# Altium schematic sheet 07 Power2.SchDoc
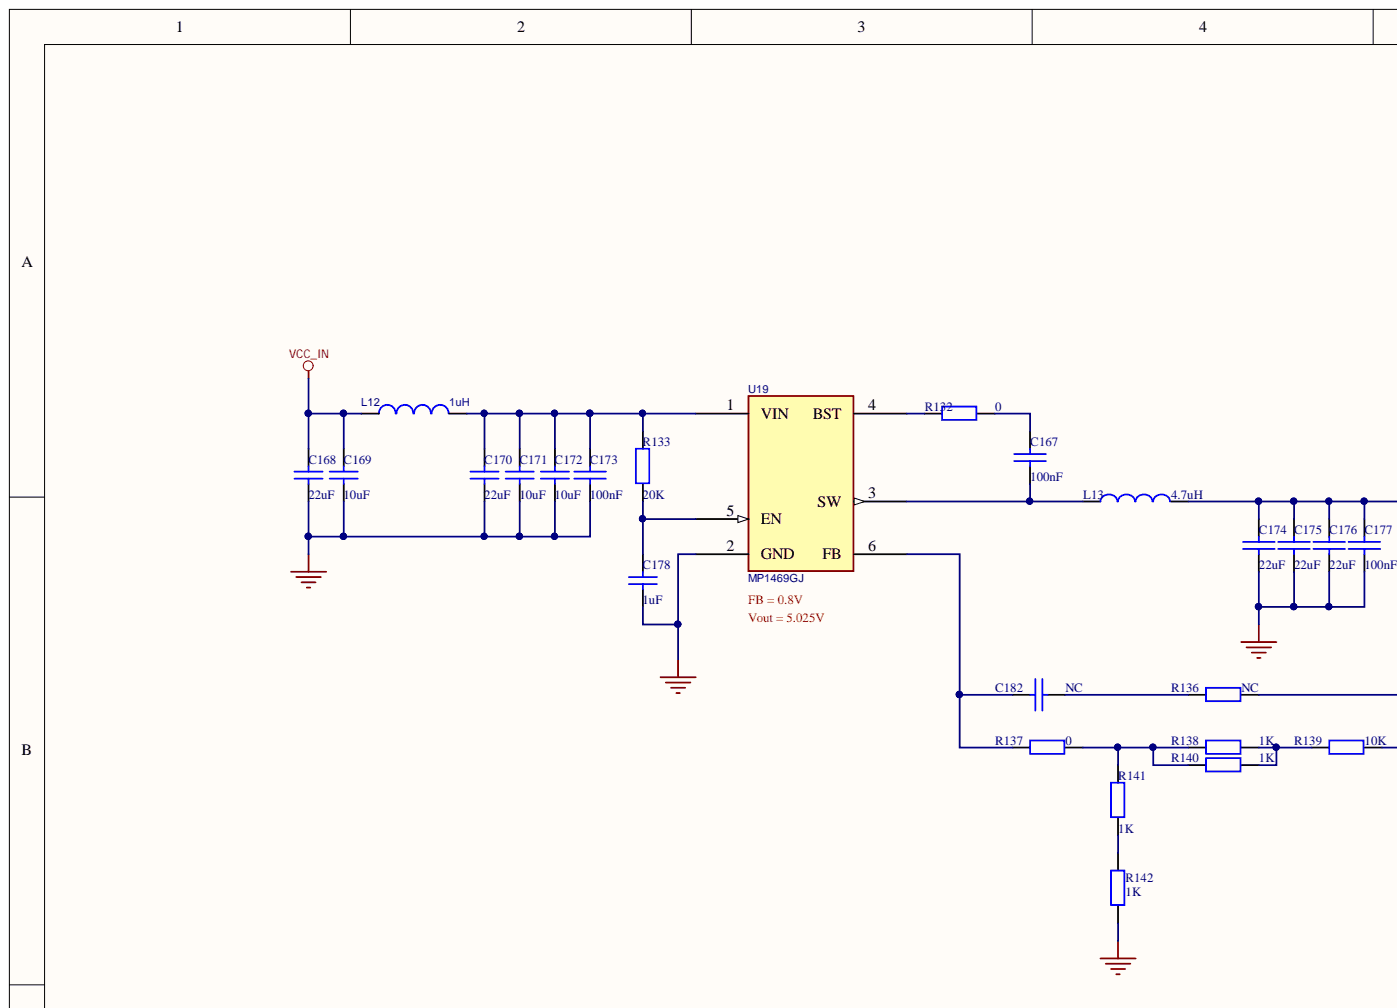
[diagram p0: 07 Power2.SchDoc, top left]
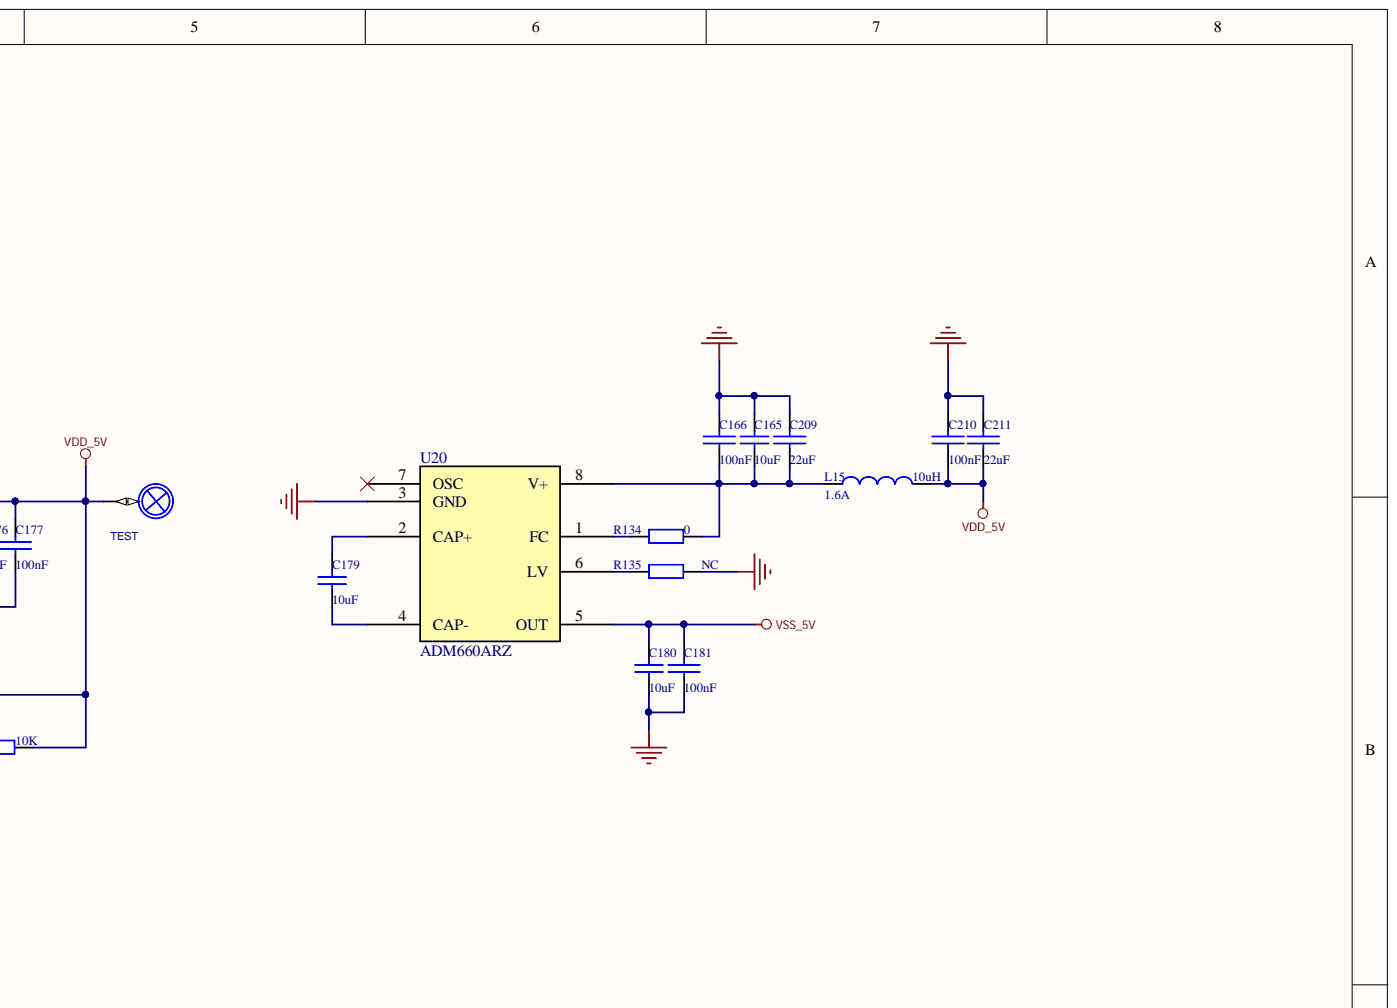
[diagram p1: 07 Power2.SchDoc, top right]
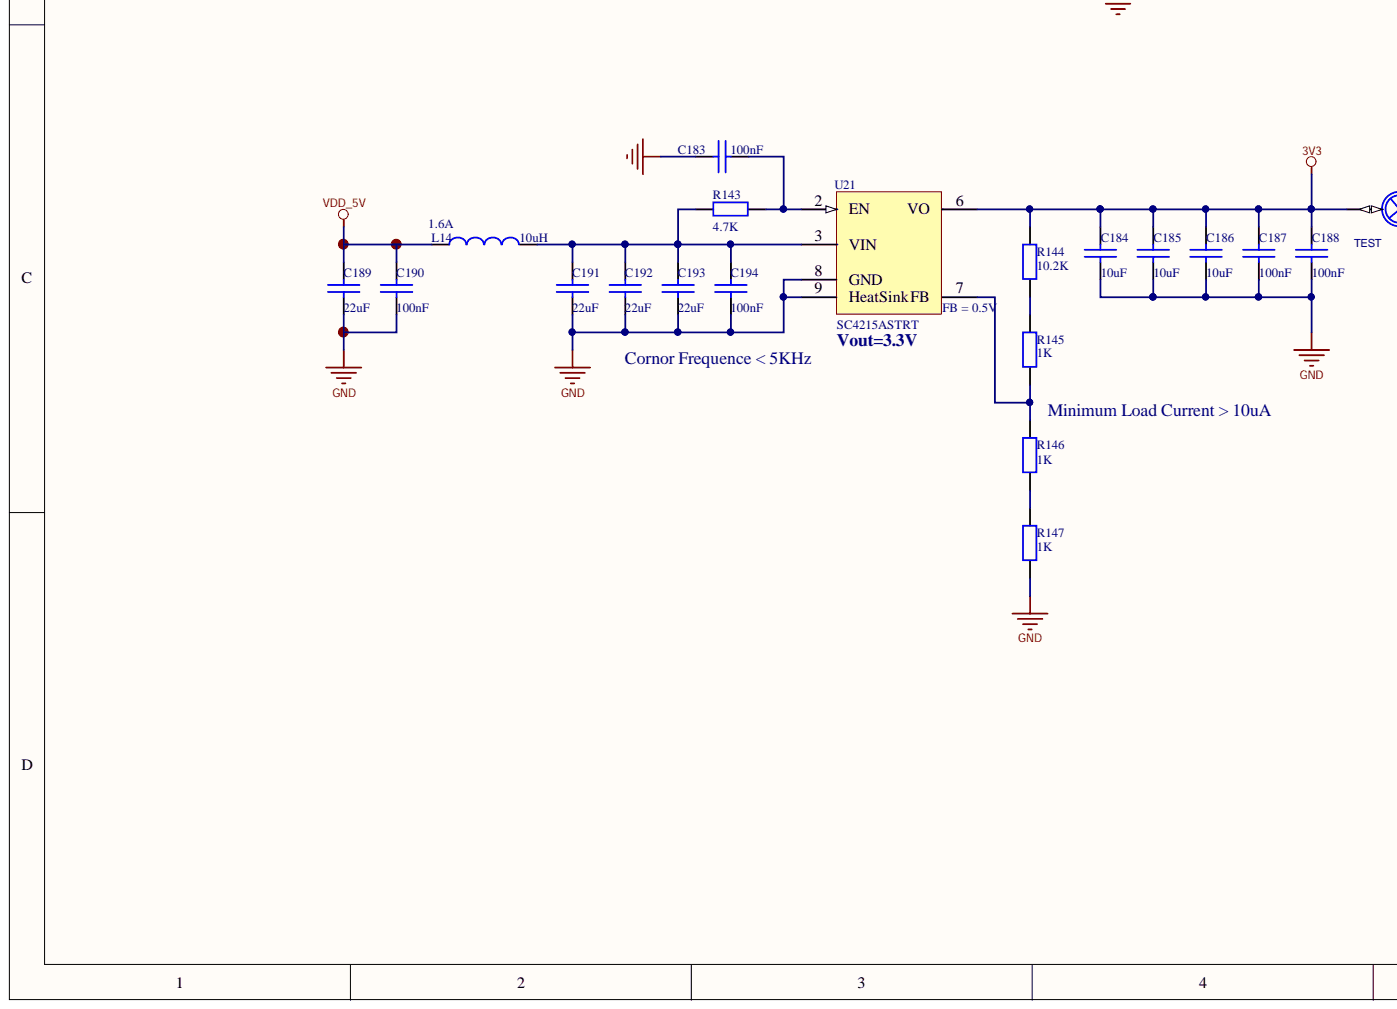
[diagram p2: 07 Power2.SchDoc, bottom left]
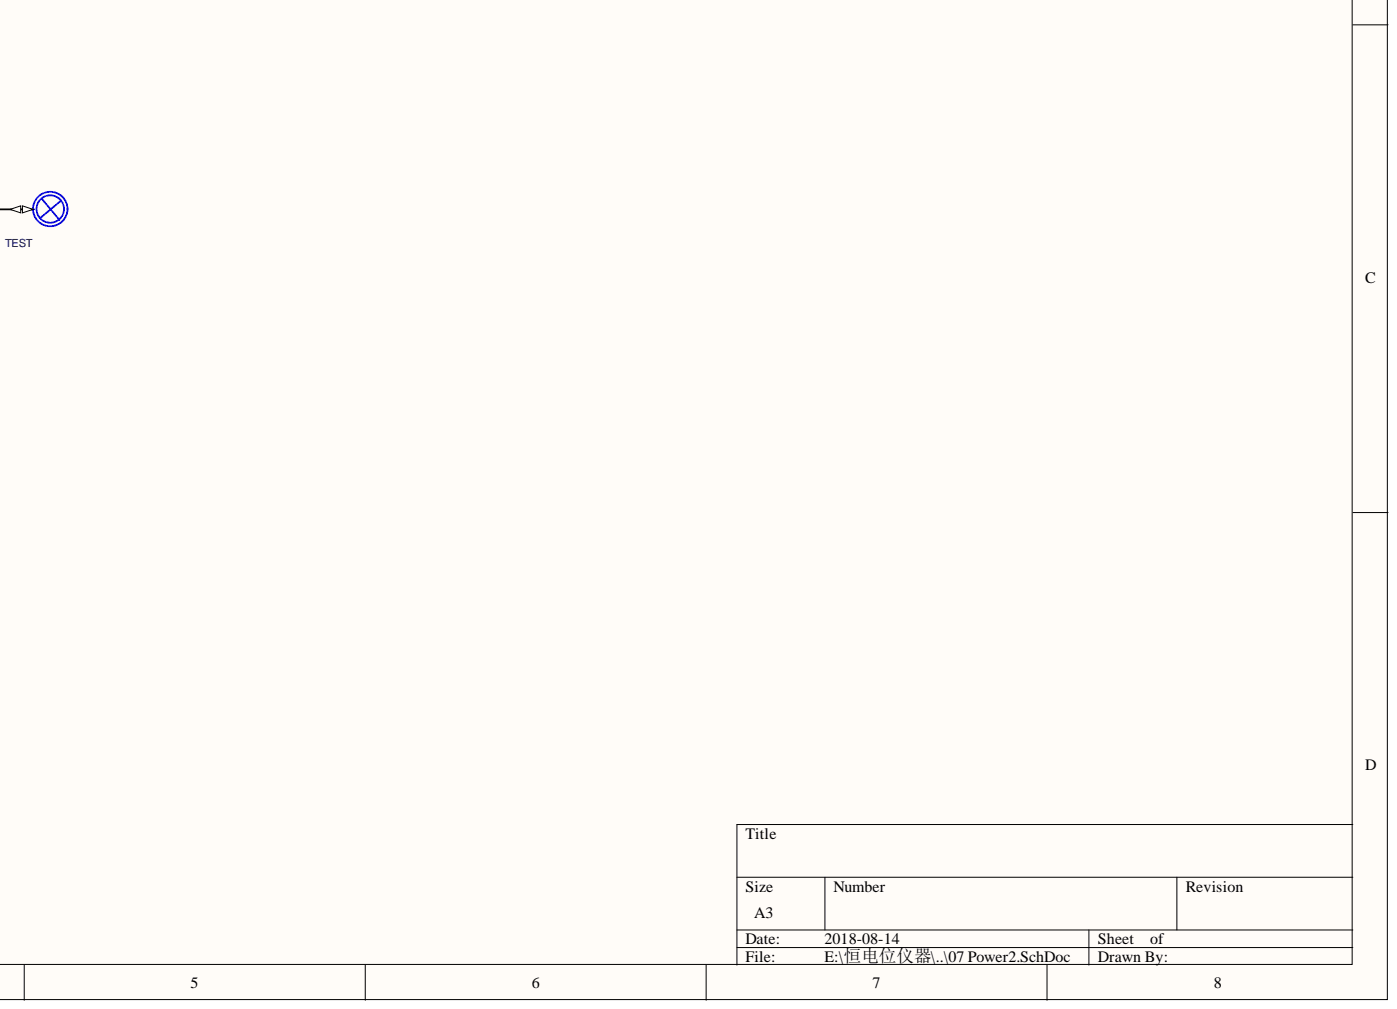
[diagram p3: 07 Power2.SchDoc, bottom right]

## Parts
C165  10uF  [SC0805]
C166  100nF  [SC0603]
C167  100nF  [SC0603]
C168  22uF  [SC0805]
C169  10uF  [SC0805]
C170  22uF  [SC0805]
C171  10uF  [SC0805]
C172  10uF  [SC0805]
C173  100nF  [SC0603]
C174  22uF  [SC0603]
C175  22uF  [SC0603]
C176  22uF  [SC0603]
C177  100nF  [SC0603]
C178  1uF  [SC0805]
C179  10uF  [SC0805]
C180  10uF  [SC0805]
C181  100nF  [SC0603]
C182  20pF  [SC0603]  (value not drawn)
C183  100nF  [SC0402]
C184  10uF  [SC0603]
C185  10uF  [SC0603]
C186  10uF  [SC0603]
C187  100nF  [SC0402]
C188  100nF  [SC0402]
C189  22uF  [SC0603]
C190  100nF  [SC0402]
C191  22uF  [SC0603]
C192  22uF  [SC0603]
C193  22uF  [SC0603]
C194  100nF  [SC0402]
C209  22uF  [SC0603]
C210  100nF  [SC0402]
C211  22uF  [SC0603]
L12  1uH  [HSL1008]
L13  value 4.7uH  [XAL4030]  (hidden comment: 贴片功率电感-4.7uH±20％-直流阻抗44.1mΩ-饱和电流4.5A)
L14  value 10uH  Saturation Current: 1.6A  [HSL4020]  (hidden comment: 终端片式电感-10uH-+/-20%-0.9A-0.215OHM-4.0*2.0)
L15  value 10uH  Saturation Current: 1.6A  [HSL4020]  (hidden comment: 终端片式电感-10uH-+/-20%-0.9A-0.215OHM-4.0*2.0)
R132  value 0  [SR0603]  (hidden comment: 0±5%)
R133  value 20K  [SR0402]  (hidden comment: 20K±1%)
R134  value 0  [SR0402]  (hidden comment: 0±5%)
R135  0±5%  [SR0402]  (value not drawn)
R136  39.2K±1%  [SR0402]  (value not drawn)
R137  value 0  [SR0402]  (hidden comment: 0±5%)
R138  value 1K  [SR0402]  (hidden comment: 1K±1%)
R139  10K±1%  value 10K  [SR0402]
R140  value 1K  [SR0402]  (hidden comment: 1K±1%)
R141  value 1K  [SR0402]  (hidden comment: 1K±1%)
R142  value 1K  [SR0402]  (hidden comment: 1K±1%)
R143  value 4.7K  [SR0402]  (hidden comment: 4.7K±1%)
R144  value 10.2K  [SR0402]  (hidden comment: 10.2K±1%)
R145  value 1K  [SR0402]  (hidden comment: 1K±1%)
R146  value 1K  [SR0402]  (hidden comment: 1K±1%)
R147  value 1K  [SR0402]  (hidden comment: 1K±1%)
TS1  TEST  [GND12]
TS2  TEST  [GND12]
U19  MP1469GJ  [TSOT23-6]
U20  ADM660ARZ  [R_8]
U21  SC4215A  value SC4215ASTRT  Device: SC4215ASTRT  [SOP8H-50-155A]

## Nets
3V3: C184.2 C185.2 C186.2 C187.1 C188.1 R144.1 TS2.1 U21.6(VO)
GND: C165.1 C166.1 C168.2 C169.2 C170.2 C171.2 C172.2 C173.2 C174.1 C175.1 C176.1 C177.2 C178.2 C180.2 C181.2 C183.2 C184.1 C185.1 C186.1 C187.2 C188.2 C189.1 C190.1 C191.1 C192.1 C193.1 C194.1 C209.2 C210.2 C211.2 R135.1 R142.1 R147.1 U19.2(GND) U20.3(GND) U21.8(GND) U21.9(HeatSink)
VCC_IN: C168.1 C169.1 L12.1
VDD_5V: C174.2 C175.2 C176.2 C177.1 C189.2 C190.2 C210.1 C211.1 L13.2 L14.1 L15.2 R136.2 R139.1 TS1.1
VSS_5V: C180.1 C181.1 U20.5(OUT)
NetC165_2: C165.2 C166.2 C209.1 L15.1 R134.2 U20.8(V+)
NetC167_1: C167.1 R132.2
NetC167_2: C167.2 L13.1 U19.3(SW)
NetC170_1: C170.1 C171.1 C172.1 C173.1 L12.2 R133.2 U19.1(VIN)
NetC178_1: C178.1 R133.1 U19.5(EN)
NetC179_1: C179.1 U20.2(CAP+)
NetC179_2: C179.2 U20.4(CAP-)
NetC182_1: C182.1 R137.2 U19.6(FB)
NetC182_2: C182.2 R136.1
NetC183_1: C183.1 R143.2 U21.2(EN)
NetC191_2: C191.2 C192.2 C193.2 C194.2 L14.2 R143.1 U21.3(VIN)
NetR132_1: R132.1 U19.4(BST)
NetR134_1: R134.1 U20.1(FC)
NetR135_2: R135.2 U20.6(LV)
NetR137_1: R137.1 R138.2 R140.2 R141.2
NetR138_1: R138.1 R139.2 R140.1
NetR141_1: R141.1 R142.2
NetR144_2: R144.2 R145.2
NetR145_1: R145.1 R146.2 U21.7(FB)
NetR146_1: R146.1 R147.2

Not connected: U20 7(OSC)

## Library data of the parts
C165 C169 C171 C172 C179 C180 = Cap Semi: Capacitor; Part_Comments: SMD陶瓷电容-25V-10uF-+/-10%-X5R-0805; Code: 101024500042; DEVICE: CC0805KKX5R8BB106; Vendor: Yageo; Voltage: 25V; Component Kind: Standard; Library Name: Miscellaneous Devices.IntLib; Signal Integrity: Cap Semi; Simulation: CAP; Value: 10uF
C166 C167 C173 C177 C181 = CAP: Capacitor; Part_Comments: SMD陶瓷电容-50V-100nF-+/-10%-X7R-0603; COMPONENT_HIGH_MAX: 0.55MM; DEVICE: CC0603KRX7R9BB104; Vendor: Yageo; Voltage: 50V; Component Kind: Standard; Value: 100nF
C168 C170 = Cap Semi: Capacitor; Part_Comments: SMD陶瓷电容-25V-22uF-+/-20%-X5R-0805; DEVICE: CC0805MKX5R8BB226; ESR: 5mOhm; Vendor: Yageo; Voltage: 25V; Component Kind: Standard; Library Name: Miscellaneous Devices.IntLib; Signal Integrity: Cap Semi; Simulation: CAP; Value: 22uF
C174-C176 C189 C191-C193 C209 C211 = CAP: Capacitor; Part_Comments: SMD陶瓷电容-10V-22uF-+/-20%-X5R-0603; COMPONENT_HIGH_MAX: 0.9MM; DEVICE: CC0603MRX5R6BB226; Vendor: Yageo; Voltage: 10V; Component Kind: Standard; Value: 22uF
C178 = Cap Semi: Capacitor; Part_Comments: SMD陶瓷电容-50V-1uF-+/-10%-X7R-0805; DEVICE: CC0805KKX7R9BB105; Vendor: Yageo; Voltage: 50V; Component Kind: Standard; Library Name: Miscellaneous Devices.IntLib; Signal Integrity: Cap Semi; Simulation: CAP; Value: 1uF
C182 = CAP: Capacitor; Part_Comments: SMD陶瓷电容-50V-20pF-+/-5%-NP0-0603; Code: 101024302001; DEVICE: CC0603JRNPO9BN200; Vendor: Yageo; Voltage: 50V; Component Kind: Standard; NC: NC; Value: 20pF
C183 C187 C188 C190 C194 C210 = CAP: Capacitor; Part_Comments: SMD陶瓷电容-10V-100nF-+/-10%-X7R-040; Code: 101024500028; COMPONENT_HIGH_MAX: 0.55MM; DEVICE: CC0402KRX5R6BB104; Vendor: Yageo; Voltage: 10V; Component Kind: Standard; Value: 100nF
C184-C186 = CAP: Capacitor; Part_Comments: SMD陶瓷电容-6.3V-10uF-+/-20%-X5R-0603; Code: 101024500091; COMPONENT_HIGH_MAX: 0.9MM; DEVICE: CC0603MRX5R5BB106; Vendor: Yageo; Voltage: 6.3V; Component Kind: Standard; Value: 10uF
L12 = IND: CODE: 101050050064; COMPONENT_HIGH_MAX: 1.2MM; Current: 2A; DEVICE: SWPA252012S1R0NT; Component Kind: Standard
L13 = INDUCTOR1: XAL4030-472ME; Saturation Current: 4.5A; Vendor: Coilcraft; Component Kind: Standard; DEVICE: XAL4030-472ME; Part_Comments: 贴片功率电感-4.7uH±20％-直流阻抗44.1mΩ-饱和电流4.5A
L14 L15 = INDUCTOR1: SDRH127H-4R7MC-12A; DEVICE: SWPA4020S100MT; Irms@40°C rise: 0.9A; Vendor: Sunlord; Component Kind: Standard; Part_Comments: 终端片式电感-10uH-+/-20%-0.9A-0.215OHM-4.0*2.0
R132 = RES2: Resistor; Part_Comments: 片式厚膜电阻器-0.1W-0OHM-+/-5%-0603; Code: 101017300001; DEVICE: RC0603JR-070RL; Vendor: Yageo; Component Kind: Standard
R133 = RES2: Resistor; Part_Comments: 片式厚膜电阻器-0.0625W-20KOHM-+/-1%-0402; Code: 101017400079; DEVICE: RC0402FR-0720KL; Vendor: Yageo; Component Kind: Standard
R134 R137 = Res2: Resistor; Part_Comments: 片式厚膜电阻器-0.0625W-0OHM-< 50MOHM-0402-1A; Code: 101017400045; DEVICE: RC0402JR_070RL; Vendor: Yageo; Component Kind: Standard; Library Name: Miscellaneous Devices.IntLib; Signal Integrity: Res; Simulation: RESISTOR
R135 = Res2: Resistor; Part_Comments: 片式厚膜电阻器-0.0625W-0OHM-< 50MOHM-0402-1A; Code: 101017400045; DEVICE: RC0402JR_070RL; Value: 0; Vendor: Yageo; Component Kind: Standard; Library Name: Miscellaneous Devices.IntLib; NC: NC; Signal Integrity: Res; Simulation: RESISTOR
R136 = RES2: Resistor; Part_Comments: 片式厚膜电阻器-0.0625W-39.2KOHM-+/-1%-0402; DEVICE: RC0402FR-0739K2L; Value: 39.2K; Vendor: Yageo; Component Kind: Standard; NC: NC
R138 R140-R142 R145-R147 = Res2: Resistor; Part_Comments: 片式厚膜电阻器-0.0625W-1KOHM-+/-1%-0402; Code: 101017400067; DEVICE: RC0402FR-071KL; Vendor: Yageo; Component Kind: Standard; Library Name: Miscellaneous Devices.IntLib; Signal Integrity: Res; Simulation: RESISTOR
R139 = RES2: Resistor; Part_Comments: 片式厚膜电阻器-0.0625W-10KOHM-+/-1%-0402; Code: 101017400055; DEVICE: RC0402FR-0710KL; Vendor: Yageo; Component Kind: Standard
R143 = Res2: Resistor; Part_Comments: 片式厚膜电阻器-0.0625W-4.7KOHM-+/-1%-0402; Code: 101017400060; DEVICE: RC0402FR-074K7L; Vendor: Yageo; Component Kind: Standard; Library Name: Miscellaneous Devices.IntLib; Signal Integrity: Res; Simulation: RESISTOR
R144 = RES2: Resistor; Part_Comments: 片式厚膜电阻器-0.0625W-10.2KOHM-+/-1%-0402; Code: 101017400018; DEVICE: RC0402FR-0710K2L; Vendor: Yageo; Component Kind: Standard
TS1 TS2 = TEST: PARTS: 1; %195%%232%%202%%246%: 测试点符号; LEVEL: STD; OriginalSymbolOrigin: (20,30); Component Kind: Standard; DEVICE: TEST; PKG_TYPE: GND12
U19 = MP1469GJ: PARTS: 1; Code: 101000050202; LEVEL: STD; Component Kind: Standard; DEVICE: MP1469GJ; PKG_TYPE: TSOT23-6
U20 = ADM660ARZ: CMOS Switched-Capacitor  Voltage Converters; Vendor: ADI; Component Kind: Standard; Value: ADM660ARZ; Library Name: Potentiostat.SchLib
U21 = SC4215A: LDO-2A; Part_Comments: LDO - VIN:1.4～5.5V - ImaxLDO芯片2A - SOIC-8EDP; Code: 101000050136; Vendor: Semtech; Component Kind: Standard; Library Name: HI3531DMO_Library.SchLib
